# Altium schematic sheet Communication.SchDoc
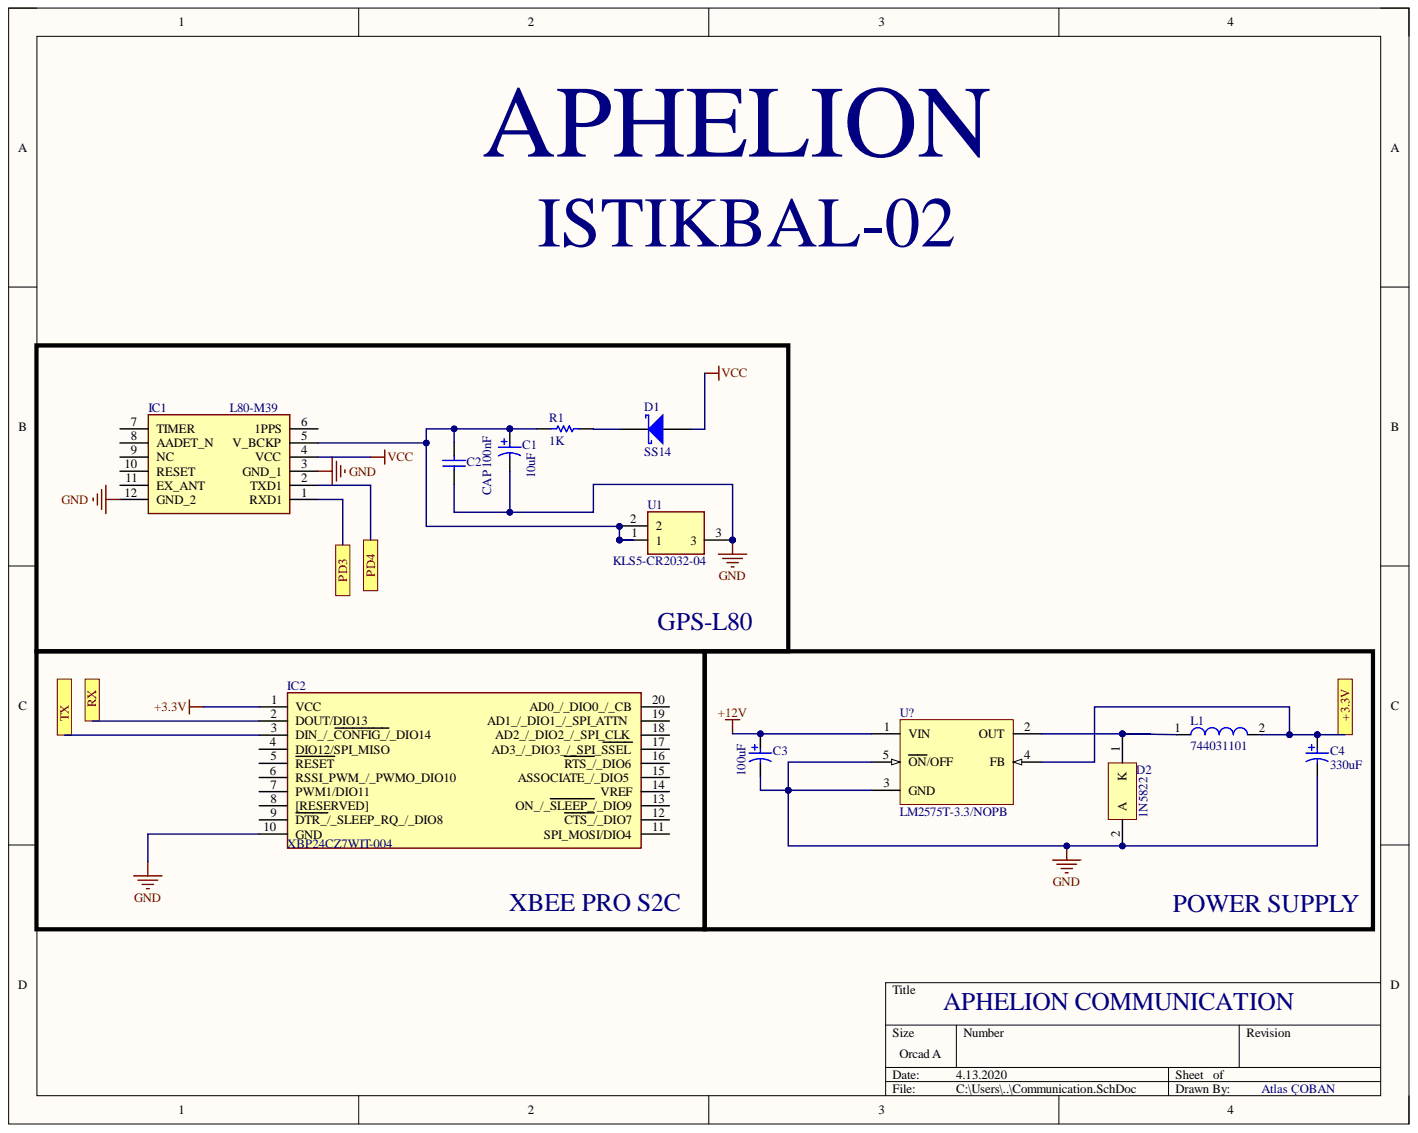
[diagram p0: Communication.SchDoc]

## Parts
C1  10uF  [CAPPR55-200-1100X500X1200]
C2  CAP 100nF  value 100nF  [CAPC1206(3216)190_M]
C3  100uF  [CAPPR65-350-1150X800X1250]
C4  330uF  [CAPPR80-750-2000X1800X2200]
D1  SS14  [FAIR-DO-214AC-2_V]
D2  1N5822  [ONSC-AXIAL_LEAD-2-267-05_V]
IC1  L80-M39  [L80-M39]
IC2  XBP24CZ7WIT-004  [XBP24CZ7WIT004]
L1  744031101  [WE-TPC-3816-S]
R1  1K  [RESC3216X60X45ML15T20]
U1  KLS5-CR2032-04  [KLS5CR203204]
U?  LM2575T-3.3/NOPB  [KC0005A]

## Ports
+3.3V, PD3, PD4, RX, TX

## Nets
+3.3V: IC2.1(VCC)
+12V: C3.1 U?.1(VIN)
GND: C1.2 C2.1 C3.2 C4.2 D2.2 IC1.3(GND_1) IC1.12(GND_2) IC2.10(GND) U1.3 U?.3(GND) U?.5(ON/OFF)
VCC: D1.2 IC1.4(VCC)
PD3: IC1.1(RXD1) ; port PD3
PD4: IC1.2(TXD1) ; port PD4
RX: IC2.2(DOUT/DIO13) ; port RX
TX: IC2.3(DIN_/_CONFIG_/_DIO14) ; port TX
NetC1_1: C1.1 C2.2 IC1.5(V_BCKP) R1.1 U1.1 U1.2
NetC4_1: C4.1 L1.2 U?.4(FB) ; port +3.3V
NetD1_1: D1.1 R1.2
NetD2_1: D2.1 L1.1 U?.2(OUT)

Not connected: IC1 6(1PPS) 7(TIMER) 8(AADET_N) 9(NC) 10(RESET) 11(EX_ANT); IC2 4(DIO12/SPI_MISO) 5(RESET) 6(RSSI_PWM_/_PWMO_DIO10) 7(PWM1/DIO11) 8([RESERVED]) 9(DTR_/_SLEEP_RQ_/_DIO8) 11(SPI_MOSI/DIO4) 12(CTS_/_DIO7) 13(ON_/_SLEEP_/_DIO9) 14(VREF) 15(ASSOCIATE_/_DIO5) 16(RTS_/_DIO6) 17(AD3_/_DIO3_/_SPI_SSEL) 18(AD2_/_DIO2_/_SPI_CLK) 19(AD1_/_DIO1_/_SPI_ATTN) 20(AD0_/_DIO0_/_CB)

## Library data of the parts
C1 = CMP-2100-03622-1: Aluminum Electrolytic Capacitor, 10 uF, +/- 20%, 50 V, -40 to 85 degC, 2-Pin THD, RoHS, Bulk; Manufacturer: Panasonic; Dielectric Material: Aluminium; Dissipation Factor: 0.12%; Lead/Base Style: Straight; Lead Diameter: 0.5mm; Leakage Current: 3uA; Life (Hours): 2000Hour; Ripple Current: 65mA; Voltage: 50V; Capacitance: 10uF; Case/Package: Radial; Component Kind: Standard; Datasheet URL: https://industrial.panasonic.com/cdbs/www-data/pdf/RDF0000/ABA0000C1218.pdf; Datasheet Version: Rev. 05, 10/2016; Diameter: 5mm; Height: 11mm; Height - Seated (Max): 0.472inch; Lead Free: LeadFree; Lead Pitch: 2mm; Length: 11mm; Library Name: Altium Content Vault; Manufacturer URL: http://www.panasonic.com/; Max Operating Temperature: 85degC; Min Operating Temperature: -40degC; Mount: ThroughHole; Number of Pins: 2; Package Description: 2-Pin Radial Lead, Dia 5 mm, Height 12 mm; Package Quantity: 200; Package Reference: CAPPR55-200-1100X500X1200; Packaging: Bulk; Radiation Hardening: No; REACH SVHC: NoSVHC; Ripple Current (AC): 65mA; RoHSCompliant: true; Termination: Radial; Tolerance: 20%; Voltage Rating: 50V; Voltage Rating (DC): 50V
C2 = CMP-1037-04189-1: CAP 100nF 25V ±5% 1206 (3216 Metric) Thickness 1.9mm SMD; Case-EIA: 1206; Case-Metric: 3216; Tolerance: Â±5%; Component Kind: Standard; Library Name: Altium Content Vault; Max Thickness: 1.9 mm; Rated Voltage: 25 V; Technology: SMT
C3 = CMP-2100-03623-1: Aluminum Electrolytic Capacitor, 100 uF, +/- 20%, 50 V, -40 to 85 degC, 2-Pin THD, RoHS, Bulk; Manufacturer: Panasonic; Dielectric Material: Aluminium; Dissipation Factor: 0.12%; Lead/Base Style: Straight; Lead Diameter: 0.6mm; Leakage Current: 3uA; Life (Hours): 2000Hour; Polarity: Polar; Ripple Current: 250mA; Voltage: 50V; Capacitance: 100uF; Case/Package: Radial; Component Kind: Standard; Datasheet URL: https://industrial.panasonic.com/cdbs/www-data/pdf/RDF0000/ABA0000C1218.pdf; Datasheet Version: Rev. 05, 10/2016; Diameter: 8mm; Height: 11.5mm; Height - Seated (Max): 0.492inch; Lead Free: LeadFree; Lead Pitch: 3.5mm; Length: 11.5mm; Library Name: Altium Content Vault; Manufacturer URL: http://www.panasonic.com/; Max Operating Temperature: 85degC; Min Operating Temperature: -40degC; Mount: ThroughHole; Number of Pins: 2; Package Description: 2-Pin Radial Lead, Dia 8 mm, Height 12.5 mm; Package Quantity: 200; Package Reference: CAPPR65-350-1150X800X1250; Packaging: Bulk; Radiation Hardening: No; REACH SVHC: NoSVHC; Ripple Current (AC): 250mA; RoHSCompliant: true; Termination: Radial; Tolerance: 20%; Voltage Rating: 50V; Voltage Rating (DC): 50V
C4 = CMP-2000-07350-1: Aluminum Electrolytic Capacitor, 330 uF, +/- 20%, 100 V, -40 to 105 degC, 2-Pin THD, RoHS, Bulk; Manufacturer: Nichicon; Capacitance: 330µF; Rated Voltage: 100V; Type: Radial; Case Size/Dimension: Ø18x20mm; Component Kind: Standard; Datasheet URL: http://products.nichicon.co.jp/en/pdf/XJA043/e-uhe.pdf; Datasheet Version: 08/2015; Library Name: Altium Content Vault; Manufacturer URL: http://www.nichicon.co.jp/english/; Mounting Technology: ThroughHole; Operating Temp-Max: 105°C; Package Description: 2-Pin Through Hole Device, Dia 18 mm, Body Length 22 mm; Package Reference: CAPPR80-750-2000X1800X2200
D1 = CMP-2000-04943-1: Schottky Rectifier, 1.1 W, -65 to 125 degC, 2-Pin SMD, RoHS, Tape and Reel; Manufacturer: Fairchild; Additional Feature: FREEWHEELINGDIODE; Average Forward Current-Max (A): 1; CAGE Code: 1HBD3,07933,59621,7D893; Configuration: SINGLE; Diode Element Material: SILICON; JEDEC-95 Code: DO-214AC; Package Body Material: PLASTIC/EPOXY; Power Dissipation Limit-Max (W): 1.1; Rep Pk Reverse Voltage-Max (V): 40; Signal Diode Type: SIGNALDIODE; Component Kind: Standard; Datasheet URL: http://www.onlinecomponents.com/datasheet/ss14.aspx?p=11369800; Datasheet Version: Oct-13; JESD-30 Code: R-PDSO-C2; JESD-97 Code: e3; Lead Free: YES; Library Name: Altium Content Vault; Manufacturer URL: https://www.fairchildsemi.com/; Moisture Sensitivity Level: 1; Number of Elements: 1; Number of Terminals: 2; Package Description: 2-Pin Surface Mount Device, Body 4.375 x 2.725 mm; Package Reference: DO-214AC-2; Package Shape: RECTANGULAR; Package Style: SMALLOUTLINE; Package URL: https://www.fairchildsemi.com/package-drawings/DO/DO214AC.pdf; Peak Reflow Temperature (Cel): 260; RoHS Compliant: YES; Surface Mount: YES; Technology: SCHOTTKY; Terminal Finish: MATTETIN; Terminal Form: CBEND; Terminal Position: DUAL
D2 = CMP-1055-00007-1: Rectifier, 2-Pin Axial_Lead, Bulk Bag; Manufacturer: On Semiconductor; Configuration: Single; IFSM Max (A): 80; Note1: 0; Component Kind: Standard; ComponentLink1Description: Manufacturer URL; ComponentLink1URL: http://www.onsemi.com/; ComponentLink2Description: Datasheet; ComponentLink2URL: http://www.onsemi.cn/pub_link/Collateral/1N5820-D.PDF; ComponentLink3Description: Package Specification; ComponentLink3URL: http://www.onsemi.com/pub_link/Collateral/267-05.PDF; DatasheetVersion: Rev. 10; Library Name: Altium Content Vault; Mounting Technology: Through Hole; PackageDescription: 2-Pin, Axial Lead, Diameter 5.05 mm; PackageReference: AXIAL_LEAD-2-267-05; PackageVersion: Rev. G, 06/2000; Packing: Bulk Bag; PartNumber: 1N5822; RoHS: FALSE
IC1 = L80-M39: Integrated Circuit; Manufacturer_Name: Quectel; Component Kind: Standard; Datasheet Link: http://docs-emea.rs-online.com/webdocs/147d/0900766b8147dbf2.pdf; Manufacturer_Part_Number: L80-M39; RS Part Number: 9084088; RS Price/Stock: http://uk.rs-online.com/web/p/products/9084088
IC2 = XBP24CZ7WIT-004: Integrated Circuit; Manufacturer_Name: Digi International; Component Kind: Standard; Datasheet Link: https://componentsearchengine.com/Datasheets/1/XBP24CZ7WIT-004.pdf; Height: 2.79mm; Manufacturer_Part_Number: XBP24CZ7WIT-004; Mouser Part Number: 888-XBP24CZ7WIT-004; Mouser Price/Stock: https://www.mouser.com/Search/Refine.aspx?Keyword=888-XBP24CZ7WIT-004; RS Part Number: 1359739; RS Price/Stock: http://uk.rs-online.com/web/p/products/1359739
L1 = CMP-0227-00344-1: SMD Shielded Tiny Power Inductor WE-TPC, L = 100 µH; Inductance: 100 ÂµH; Component Kind: Standard; ComponentLink1Description: Manufacturer Link; ComponentLink1URL: http://www.we-online.com; ComponentLink2Description: Datasheet; ComponentLink2URL: http://www.we-online.de/katalog/media/pdf/744031101.pdf; DatasheetVersion: ver 6, Jan-2009; Library Name: Altium Content Vault; PackageDescription: SMD Inductor, 2-Leads, Body 3.8 x 3.8 mm; PackageReference: WE-TPC-3816-S; PackageVersion: 2009
R1 = CMP-2100-03616-1: Chip Resistor, 1 KOhm, +/- 1%, 0.25 W, -55 to 155 degC, 1206 (3216 Metric), RoHS, Tape and Reel; Manufacturer: Vishay Semiconductor; Max Power Dissipation: 250mW; Resistance: 1KOhm; Temperature Coefficient: 100ppm/degreesC; Voltage Rating: 200V; Case/Package: 1206; Case Code (Imperial): 1206; Case Code (Metric): 3216; Component Kind: Standard; Composition: ThickFilm; Contact Plating: Tin; Datasheet URL: http://www.farnell.com/datasheets/1720486.pdf; Datasheet Version: 41061.0; Depth: 1.6mm; Height: 0.55mm; Lead Free: LeadFree; Length: 3.2mm; Library Name: Altium Content Vault; Manufacturer URL: http://www.vishay.com/; Max Operating Temperature: 155degC; Military Standard: Not; Min Operating Temperature: -55degC; Mount: SurfaceMount; Number of Pins: 2; Number of Terminations: 2; Package Description: 2-Pin Surface Mount Device, Body 3.15 x 1.6 mm; Package Quantity: 10; Package Reference: 1206.0; Packaging: TapeandReel; Power Rating: 250mW; Radiation Hardening: No; REACH SVHC: Unknown; RoHSCompliant: true; Termination: SMD/SMT; Tolerance: 1%; Voltage Rating (DC): 200V; Weight: 0.000353oz; Width: 0.063inch
U1 = KLS5-CR2032-04: Undefined or Miscellaneous; Manufacturer_Name: KLS Electronic; Component Kind: Standard; Datasheet Link: http://www.klsele.com/admin/product_upload/20151124101114KLS5-CR2032-04.pdf; Height: 18.13mm; Manufacturer_Part_Number: KLS5-CR2032-04
U? = CMP-0069-02027-3: SIMPLE SWITCHER® 1A Step-Down Voltage Regulator, 5-pin TO-220, Pb-Free; Manufacturer: Texas Instruments; PackageTopMarking: NSUZXYTTE#*LM2575T*-3.3 P+; PowerWise: N; Code_JEDEC: TO-220-AB; Component Kind: Standard; ComponentLink1Description: Datasheet URL; ComponentLink1URL: http://www.ti.com/general/docs/lit/getliterature.tsp?genericPartNumber=LM2575-N&fileType=pdf; CompType: Switcher; Library Name: Altium Content Vault; PackageDescription: TO-220, Molded, 5 Lead, Straight; PackageReference: KC0005A; PinCount: 5; RoHS: Y
